# Altium schematic sheet POWER.SCHDOC
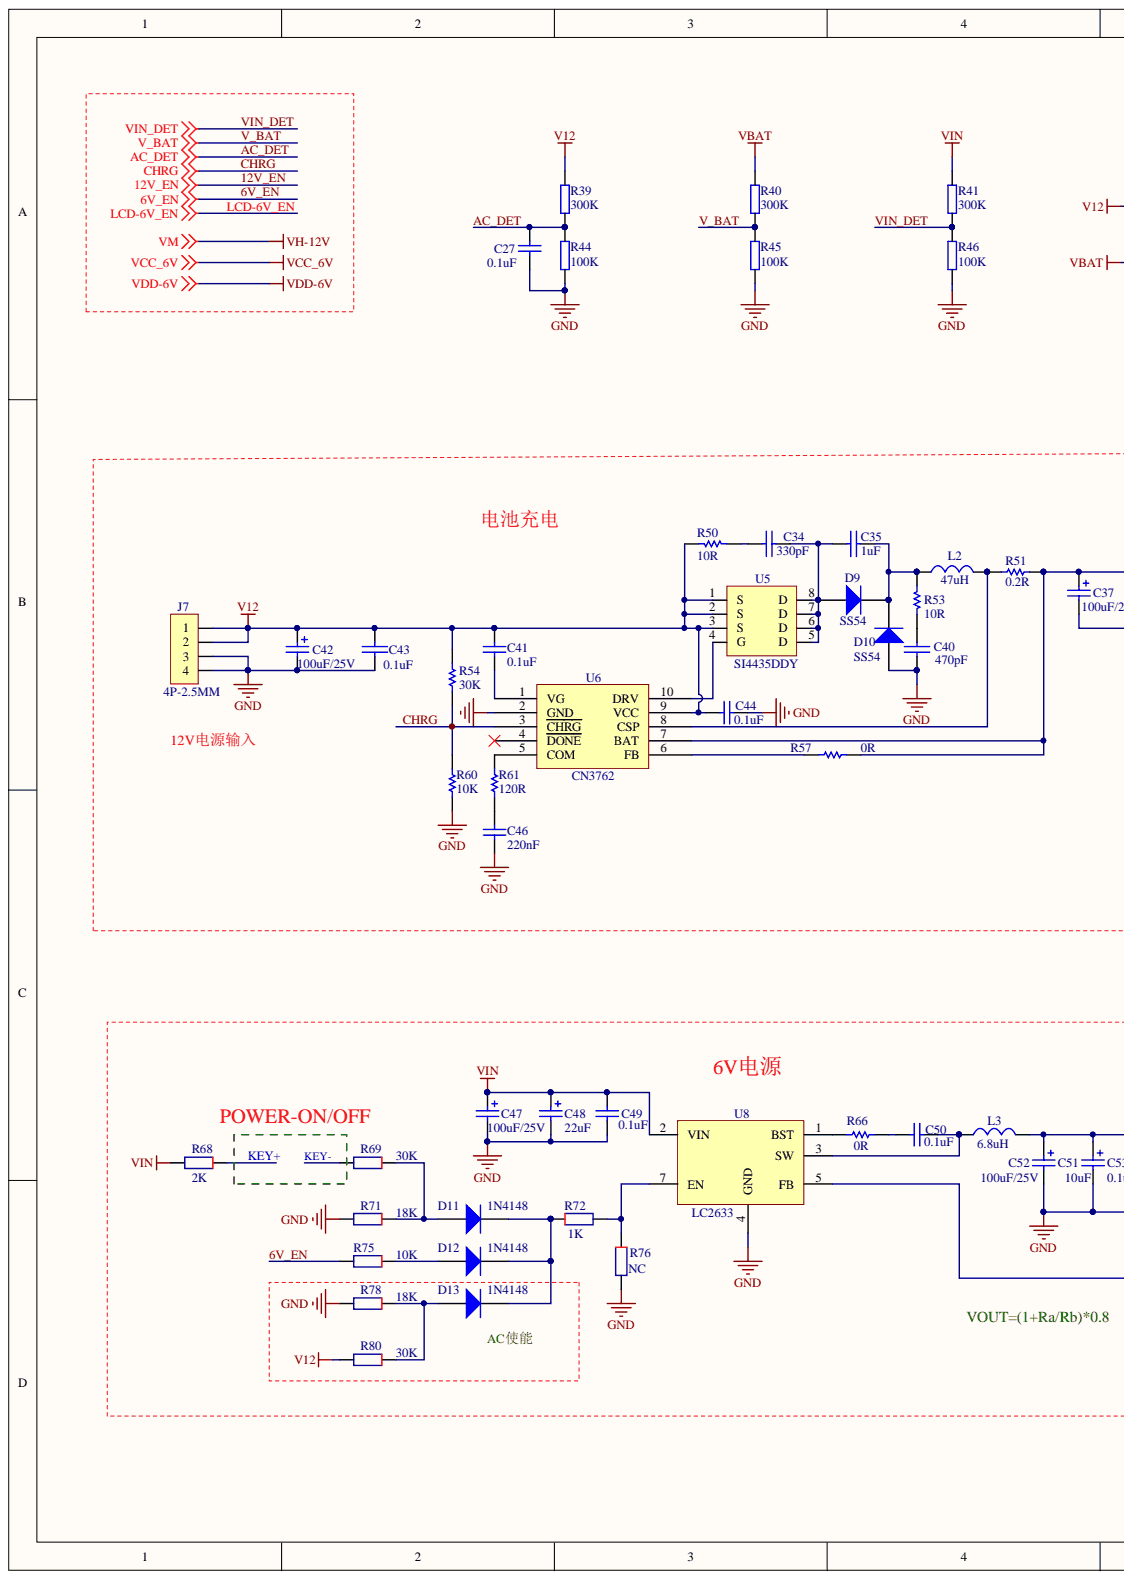
[diagram p0: POWER.SCHDOC, left]
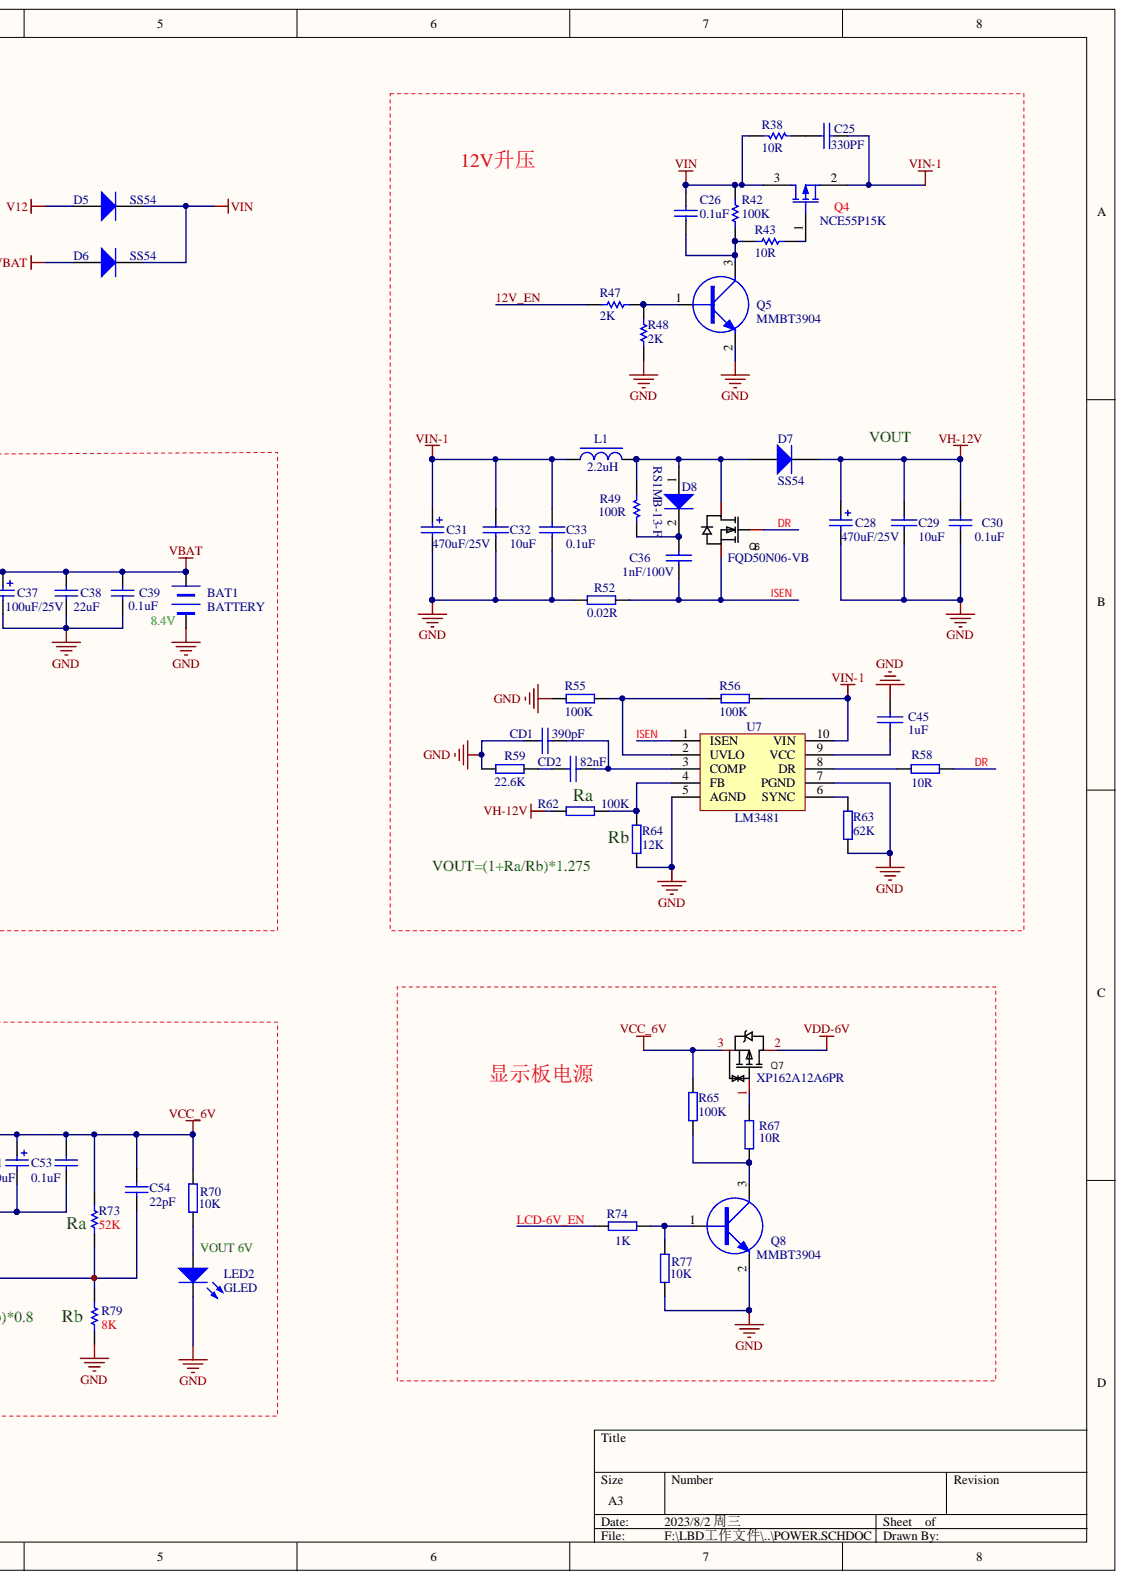
[diagram p1: POWER.SCHDOC, right]

## Parts
BAT1  BATTERY  [RBD-A01M-A-M5]
C25  330PF  [C0603]
C26  0.1uF  [C0402]
C27  0.1uF  [C0402]
C28  470uF/25V  [RTV-10]
C29  10uF  [C0603]
C30  0.1uF  [C0402]
C31  470uF/25V  [RTV-10]
C32  10uF  [C0603]
C33  0.1uF  [C0402]
C34  330pF  [C0603]
C35  1uF  [C0603]
C36  1nF/100V  [C1206]
C37  100uF/25V  [RTV-6.3]
C38  22uF  [C0805]
C39  0.1uF  [C0402]
C40  470pF  [C0603]
C41  0.1uF  [C0402]
C42  100uF/25V  [RTV-6.3]
C43  0.1uF  [C0402]
C44  0.1uF  [C0402]
C45  1uF  [C0603]
C46  220nF  [C0402]
C47  100uF/25V  [RTV-6.3]
C48  22uF  [C0805]
C49  0.1uF  [C0402]
C50  0.1uF  [C0402]
C51  10uF  [C0805]
C52  100uF/25V  [RTV-6.3]
C53  0.1uF  [C0402]
C54  22pF  [C0402]
CD1  390pF  [C0402]
CD2  82nF  [C0402]
D5  SS54  [DO_214AA]
D6  SS54  [DO_214AA]
D7  SS54  [DO-214AB]
D8  RS1MB-13-F  [DO_214AA]
D9  SS54  [DO_214AA]
D10  SS54  [DO_214AA]
D11  1N4148  [sod-323]
D12  1N4148  [sod-323]
D13  1N4148  [sod-323]
J7  4P-2.5MM  [CON4/2.5/SMD]
L1  2.2uH  [IND-SMD_L7.0-W6.6]
L2  47uH  [IND-SMD_L8.5-W8.0_PCC-M0854M]
L3  6.8uH  [IND-SMD_L7.0-W6.6]
LED2  GLED  [LED0603-G]
Q4  NCE55P15K  [TO-252]
Q5  MMBT3904  [SOT-23]
Q6  FQD50N06-VB  [TO-252]
Q7  XP162A12A6PR  [SOT-89-3_L4.5-W2.5-P1.50-LS4.2-BR]
Q8  MMBT3904  [SOT_23]
R38  10R  [R0603]
R39  300K  [R0402]
R40  300K  [R0402]
R41  300K  [R0402]
R42  100K  [R0402]
R43  10R  [R0402]
R44  100K  [R0402]
R45  100K  [R0402]
R46  100K  [R0402]
R47  2K  [R0402]
R48  2K  [R0402]
R49  100R  [R1206]
R50  10R  [R0603]
R51  0.2R  [R2512]
R52  0.02R  [R1206]
R53  10R  [R0603]
R54  30K  [R0402]
R55  100K  [R0402]
R56  100K  [R0402]
R57  0R  [R0603]
R58  10R  [R0402]
R59  22.6K  [R0402]
R60  10K  [R0402]
R61  120R  [R0402]
R62  100K  [R0402]
R63  62K  [R0402]
R64  12K  [R0402]
R65  100K  [R0402]
R66  0R  [R0402]
R67  10R  [R0402]
R68  2K  [R0402]
R69  30K  [R0402]
R70  10K  [R0402]
R71  18K  [R0402]
R72  1K  [R0402]
R73  52K  [R0402]
R74  1K  [R0402]
R75  10K  [R0402]
R76  NC  [R0402]
R77  10K  [R0402]
R78  18K  [R0402]
R79  8K  [R0402]
R80  30K  [R0402]
U5  SI4435DDY  [SO-8]
U6  CN3762  [SSOP10]
U7  LM3481  [MSOP10]
U8  LC2633  [SO-8_PAD]

## Nets
GND: BAT1.2 C27.1 C28.2 C29.1 C30.2 C31.2 C32.1 C33.1 C37.2 C38.1 C39.1 C40.1 C42.2 C43.2 C44.1 C45.1 C46.1 C47.2 C48.2 C49.1 C51.2 C52.2 C53.2 CD1.1 D10.1 J7.3 J7.4 LED2.2 Q5.2 Q8.2 R44.1 R45.1 R46.1 R48.2 R52.2 R55.1 R59.1 R60.1 R63.2 R64.2 R71.2 R76.2 R77.1 R78.2 R79.2 U6.2(GND) U7.5(AGND) U7.7(PGND) U8.4(GND)
V12: C41.2 C42.1 C43.1 C44.2 D5.1 J7.1 J7.2 R39.2 R50.1 R54.2 R80.2 U5.1(S) U5.2(S) U5.3(S) U6.9(VCC)
VBAT: BAT1.1 C37.1 C38.2 C39.2 D6.1 R40.2 R51.1 R57.1 U6.7(BAT)
VCC_6V: C51.1 C52.1 C53.1 C54.2 L3.2 Q7.3 R65.2 R70.2 R73.1
VDD-6V: Q7.2
VIN: C26.2 C47.1 C48.1 C49.2 D5.2 D6.2 Q4.3 R38.1 R41.2 R42.2 R68.2 U8.2(VIN)
VIN-1: C25.2 C31.1 C32.2 C33.2 L1.1 Q4.2 R56.2 U7.10(VIN)
VM: C28.1 C29.2 C30.1 D7.2 R62.2
6V_EN: R75.2
12V_EN: R47.2
AC_DET: C27.2 R39.1 R44.2
CHRG: R54.1 R60.2 U6.3(CHRG)
DR: Q6.1 R58.2
ISEN: C36.1 Q6.3 R52.1 U7.1(ISEN)
KEY+: R68.1
KEY-: R69.2
LCD-6V_EN: R74.2
V_BAT: R40.1 R45.2
VIN_DET: R41.1 R46.2
NetC25_1: C25.1 R38.2
NetC26_1: C26.1 Q5.3 R42.1 R43.1
NetC34_1: C34.1 C35.1 D9.1 U5.5(D) U5.6(D) U5.7(D) U5.8(D)
NetC34_2: C34.2 R50.2
NetC35_2: C35.2 D9.2 D10.2 L2.1 R53.2
NetC36_2: C36.2 D8.2 R49.1
NetC40_2: C40.2 R53.1
NetC41_1: C41.1 U6.1(VG)
NetC45_2: C45.2 U7.9(VCC)
NetC46_2: C46.2 R61.1
NetC50_1: C50.1 L3.1 U8.3(SW)
NetC50_2: C50.2 R66.2
NetC54_1: C54.1 R73.2 R79.1 U8.5(FB)
NetCD1_2: CD1.2 CD2.2 U7.3(COMP)
NetCD2_1: CD2.1 R59.2
NetD7_1: D7.1 D8.1 L1.2 Q6.2 R49.2
NetD11_1: D11.1 R69.1 R71.1
NetD11_2: D11.2 D12.2 D13.2 R72.1
NetD12_1: D12.1 R75.1
NetD13_1: D13.1 R78.1 R80.1
NetL2_2: L2.2 R51.2 U6.8(CSP)
NetLED2_1: LED2.1 R70.1
NetQ4_1: Q4.1 R43.2
NetQ5_1: Q5.1 R47.1 R48.1
NetQ7_1: Q7.1 R67.1
NetQ8_1: Q8.1 R74.1 R77.2
NetQ8_3: Q8.3 R65.1 R67.2
NetR55_2: R55.2 R56.1 U7.2(UVLO)
NetR57_2: R57.2 U6.6(FB)
NetR58_1: R58.1 U7.8(DR)
NetR61_2: R61.2 U6.5(COM)
NetR62_1: R62.1 R64.1 U7.4(FB)
NetR63_1: R63.1 U7.6(SYNC)
NetR66_1: R66.1 U8.1(BST)
NetR72_2: R72.2 R76.1 U8.7(EN)
NetU5_4: U5.4(G) U6.10(DRV)

Not connected: U6 4(DONE)

## Library data of the parts
BAT1 = BATTERY: 纽扣电池，SMD; Component Kind: Standard; Library Name: Integrated_Library.IntLib
C25 C34-C36 C40 C43 C45 CD1 CD2 = CAP: Component Kind: Standard
  C45 CD1 CD2: Library Name: Integrated_Library.IntLib
C26 C27 C30 C38 C39 C41 C44 C46 C49 C50 C53 C54 = Cap: Capacitor; Value: 100pF; Component Kind: Standard; LatestRevisionDate: 17-Jul-2002; LatestRevisionNote: Re-released for DXP Platform.; Library Name: Miscellaneous Devices.IntLib; PackageReference: RAD-0.3; Published: 8-Jun-2000; Publisher: Altium Limited
  C26 C27 C38 C39 C41 C44 C46 C49 C50 C53 C54: Simulation: CAP
C28 C31 C47 C48 C51 C52 = Cap Pol2: Polarized Capacitor (Axial); Value: 100pF; Component Kind: Standard; LatestRevisionDate: 17-Jul-2002; LatestRevisionNote: Re-released for DXP Platform.; Library Name: Miscellaneous Devices.IntLib; PackageReference: POLAR0.8; Published: 8-Jun-2000; Publisher: Altium Limited
  C47 C48 C51 C52: Simulation: CAP
C29 C32 C33 = CAP: DescriptionExample: 0.1uF,0402,±5%,16V,贴片电容,X5R; Component Kind: Standard; Library Name: Integrated_Library.IntLib
C37 C42 = CAP-POL2: DescriptionExample: 100uF,SMD,6.3*7.7MM,16V,铝电解电容; Component Kind: Standard; Library Name: Integrated_Library.IntLib; HelpURL: F:\LiBrary of YJ\芯片资料\电容\容强铝电解电容规格书.PDF
D5-D13 = DIODE: Component Kind: Standard
  D5-D7 D9-D13: Library Name: Integrated_Library.IntLib
  D8: Library Name: MY.SchLib
J7 = CON4: Component Kind: Standard; Library Name: Integrated_Library.IntLib
L1 = Ferrite Beads: Component Kind: Standard; Library Name: Integrated_Library.IntLib
L2 L3 = DIANGAN: Component Kind: Standard; Library Name: MY.SchLib
LED2 = LED: Component Kind: Standard; Library Name: Integrated_Library.IntLib
Q4 = MOSFET-P: Component Kind: Standard; Library Name: MY.SchLib
Q5 Q8 = NPN: Component Kind: Standard
Q6 = FQD50N06-VB: Add into BOM: yes; Continuous Drain Current (Id): 50A; Drain Source Voltage (Vdss): 60V; Component Kind: Standard; Convert to PCB: yes; Datasheet: https://atta.szlcsc.com/upload/public/pdf/source/20200819/C725048_F67752C7CD3519B8F15DC2D1613F5550.pdf; Device: FQD50N06-VB; Gate Threshold Voltage (Vgs(th)@Id): -; Input Capacitance (Ciss@Vds): -; JLCPCB Part Class: Extended Part; Manufacturer Part: FQD50N06-VB; Name: FQD50N06-VB; Power Dissipation (Pd): -; Reverse Transfer Capacitance (Crss@Vds): -; Supplier: LCSC; Supplier Footprint: TO-252; Supplier Part: C725048; Symbol: FQD50N06; Total Gate Charge (Qg@Vgs): -
Q7 = XP162A12A6PR: Add into BOM: yes; Continuous Drain Current (Id): 2.5A; Drain Source Voltage (Vdss): 20V; Gate Threshold Voltage (Vgs(th)@Id): 1.2V@1mA; Power Dissipation (Pd): 2W; Component Kind: Standard; Convert to PCB: yes; Datasheet: https://atta.szlcsc.com/upload/public/pdf/source/20170428/1493376748149.pdf; Device: XP162A12A6PR; JLCPCB Part Class: Extended Part; Manufacturer Part: XP162A12A6PR; Name: XP162A12A6PR; PCB3D: SOT-89-3_L4.3-W2.5-H1.6-LS4.1-P1.50; Supplier: LCSC; Supplier Footprint: SOT-89-3; Supplier Part: C108092; Symbol: XP162A12A6PR
R38 R42 R43 R47-R51 R53 R54 R57 R60 R61 R66 R73 R79 = RES2: Component Kind: Standard; Library Name: MY.SchLib
R39-R41 R44-R46 R52 R55 R56 R58 R59 R62-R65 R67 R70 R74 R77 = RES1: Component Kind: Standard; Library Name: Integrated_Library.IntLib
R68 R69 R71 R72 R75 R76 R78 R80 = Res2: Resistor; Component Kind: Standard; LatestRevisionDate: 17-Jul-2002; LatestRevisionNote: Re-released for DXP Platform.; PackageDescription: Axial Device, Thru-Hole; 2 Leads; 0.4 in Pin Spacing; PackageReference: AXIAL-0.4; Published: 8-Jun-2000; Simulation: RESISTOR; Library Name: Liuqi_Part.SchLib; Publisher: Altium Limited
U5 = SI4435: Component Kind: Standard; Library Name: MY.SchLib
U6 = CN3762: Component Kind: Standard; Library Name: MY.SchLib
U7 = LM3481: Component Kind: Standard; Library Name: MY.SchLib
U8 = LC2633: Component Kind: Standard; Library Name: MY.SchLib
